# Altium schematic sheet PAGE08 System Power.SchDoc
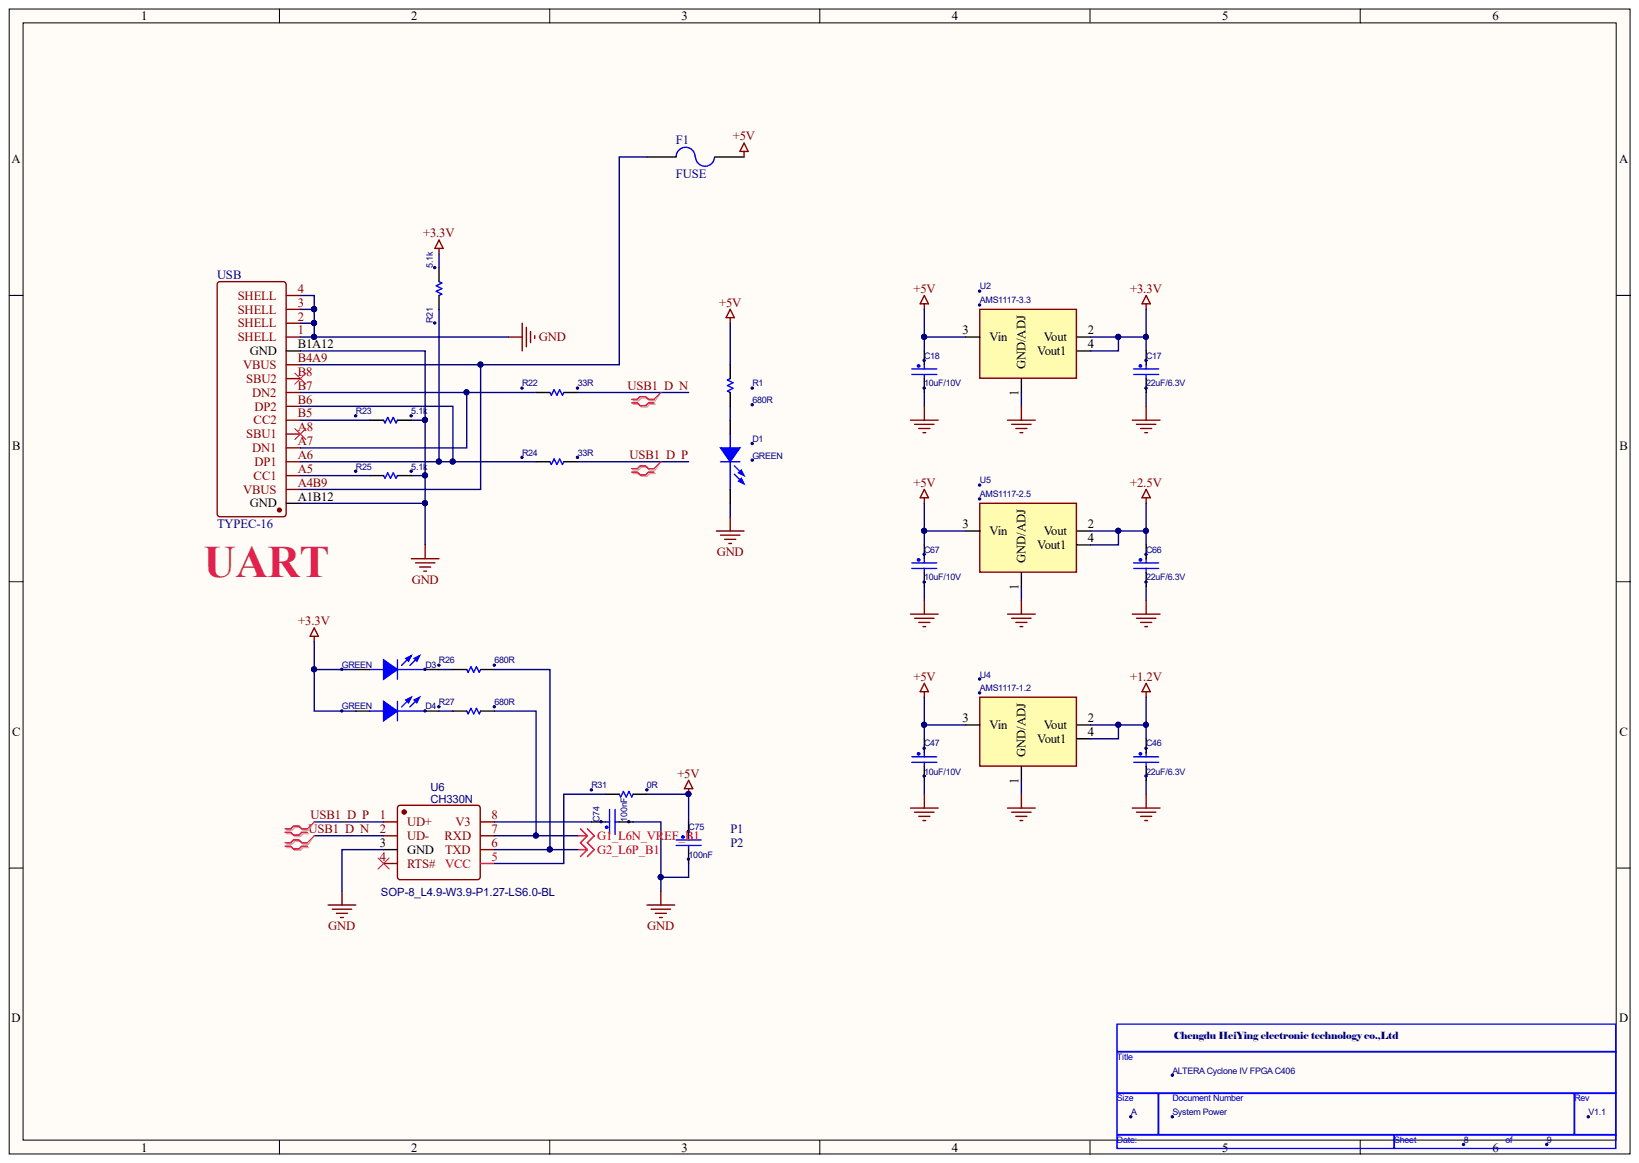
[diagram p0: PAGE08 System Power.SchDoc]

## Parts
C17  22uF/6.3V  [C0805]
C18  10uF/10V  [C0805]
C46  22uF/6.3V  [C0805]
C47  10uF/10V  [C0805]
C66  22uF/6.3V  [C0805]
C67  10uF/10V  [C0805]
C74  100nF  [C0402]
C75  100nF  [C0402]
D1  GREEN  [Q0603]
D3  GREEN  [Q0603]
D4  GREEN  [Q0603]
F1  FUSE  [1206_]
R1  680R  [R0402]
R21  5.1k  [R0402]
R22  33R  [R0402]
R23  5.1k  [R0402]
R24  33R  [R0402]
R25  5.1k  [R0402]
R26  680R  [R0402]
R27  680R  [R0402]
R31  0R  [R0402]
U2  AMS1117-3.3  [SOT-223]
U4  AMS1117-1.2  [SOT-223]
U5  AMS1117-2.5  [SOT-223]
U6  CH330N  [SOP-8_L4.9-W3.9-P1.27-LS6.0-BL]
USB  TYPEC-16  [USB-C-16PIN]

## Nets
+1.2V: C46.1 U4.2(Vout) U4.4(Vout1)
+2.5V: C66.1 U5.2(Vout) U5.4(Vout1)
+3.3V: C17.1 D3.1 D4.1 R21.1 U2.2(Vout) U2.4(Vout1)
+5V: C18.1 C47.1 C67.1 C75.1 F1.2 R1.1 R31.1 U2.3(Vin) U4.3(Vin) U5.3(Vin)
G1_L6N_VREF_B1: R27.1 U6.7(RXD)
G2_L6P_B1: R26.1 U6.6(TXD)
GND: C17.2 C18.2 C46.2 C47.2 C66.2 C67.2 C74.2 C75.2 D1.2 R23.1 R25.1 U2.1(GND/ADJ) U4.1(GND/ADJ) U5.1(GND/ADJ) U6.3(GND) USB.1(SHELL) USB.2(SHELL) USB.3(SHELL) USB.4(SHELL) USB.A1B12(GND) USB.B1A12(GND)
USB1_D_N: R22.1 U6.2(UD-)
USB1_D_P: R24.1 U6.1(UD+)
NetC74_1: C74.1 U6.8(V3)
NetD1_1: D1.1 R1.2
NetD3_2: D3.2 R26.2
NetD4_2: D4.2 R27.2
NetF1_1: F1.1 USB.A4B9(VBUS) USB.B4A9(VBUS)
NetR21_2: R21.2 R24.2 USB.A6(DP1) USB.B6(DP2)
NetR22_2: R22.2 USB.A7(DN1) USB.B7(DN2)
NetR23_2: R23.2 USB.B5(CC2)
NetR25_2: R25.2 USB.A5(CC1)
NetR31_2: R31.2 U6.5(VCC)

Not connected: U6 4(RTS#); USB A8(SBU1) B8(SBU2)

## Library data of the parts
C17 C46 C66 = CAP_NP: Capacitor, 10u, 0805, X6S, 10%, 10V; AGILE_PN: 150-75429; CAD_APPROVED: Yes; FPLIST: CC0201,CC0201_HD,CC0201_3PIN,0201_CC; MFG_NAME01: MURATA; MFG_PN01: GRM31CR71A106KA01L; Component Kind: Standard; HEIGHT: 0.33MM; ROHS: Yes; Tolerance: 10%
C18 C47 C67 = CAP_NP: CAP CER 22UF 16V 10%; AGILE_PN: 150-75429; CAD_APPROVED: Yes; FPLIST: CC0201,CC0201_HD,CC0201_3PIN,0201_CC; MFG_NAME01: Murata; MFG_PN01: GRM033R60J104KE19D; Component Kind: Standard; HEIGHT: 0.33MM; ROHS: Yes; Tolerance: 10%
C74 C75 = CAP_NP: CAP CER 0.1UF 6.3V 10% X5R 0201; AGILE_PN: 150-75429; CAD_APPROVED: Yes; FPLIST: CC0201,CC0201_HD,CC0201_3PIN,0201_CC; MFG_NAME01: MURATA; MFG_PN01: GRM033R60J104KE19D; Component Kind: Standard; HEIGHT: 0.33MM; ROHS: Yes; Tolerance: 10%
D1 D3 D4 = LED: ROOM: POWER; Component Kind: Standard
R1 R21-R27 R31 = SMALL R1: Component Kind: Standard
U2 U4 U5 = AMS1117: Component Kind: Standard
U6 = CH330N: BOM_Manufacturer: WCH; Package Display: Yes; BOM_Manufacturer Part: CH330N; BOM_Supplier: LCSC; BOM_Supplier Part: C108996; Component Kind: Standard; spicePre: U; spiceSymbolName: CH330N
USB = USB-C-16PIN: Component Kind: Standard; Library Name: USB.IntLib
F1 = FUSE: Component Kind: Standard; Library Name: 保险丝.IntLib
